# Altium schematic sheet Sensors_Sch.SchDoc(Sensors_Sch)
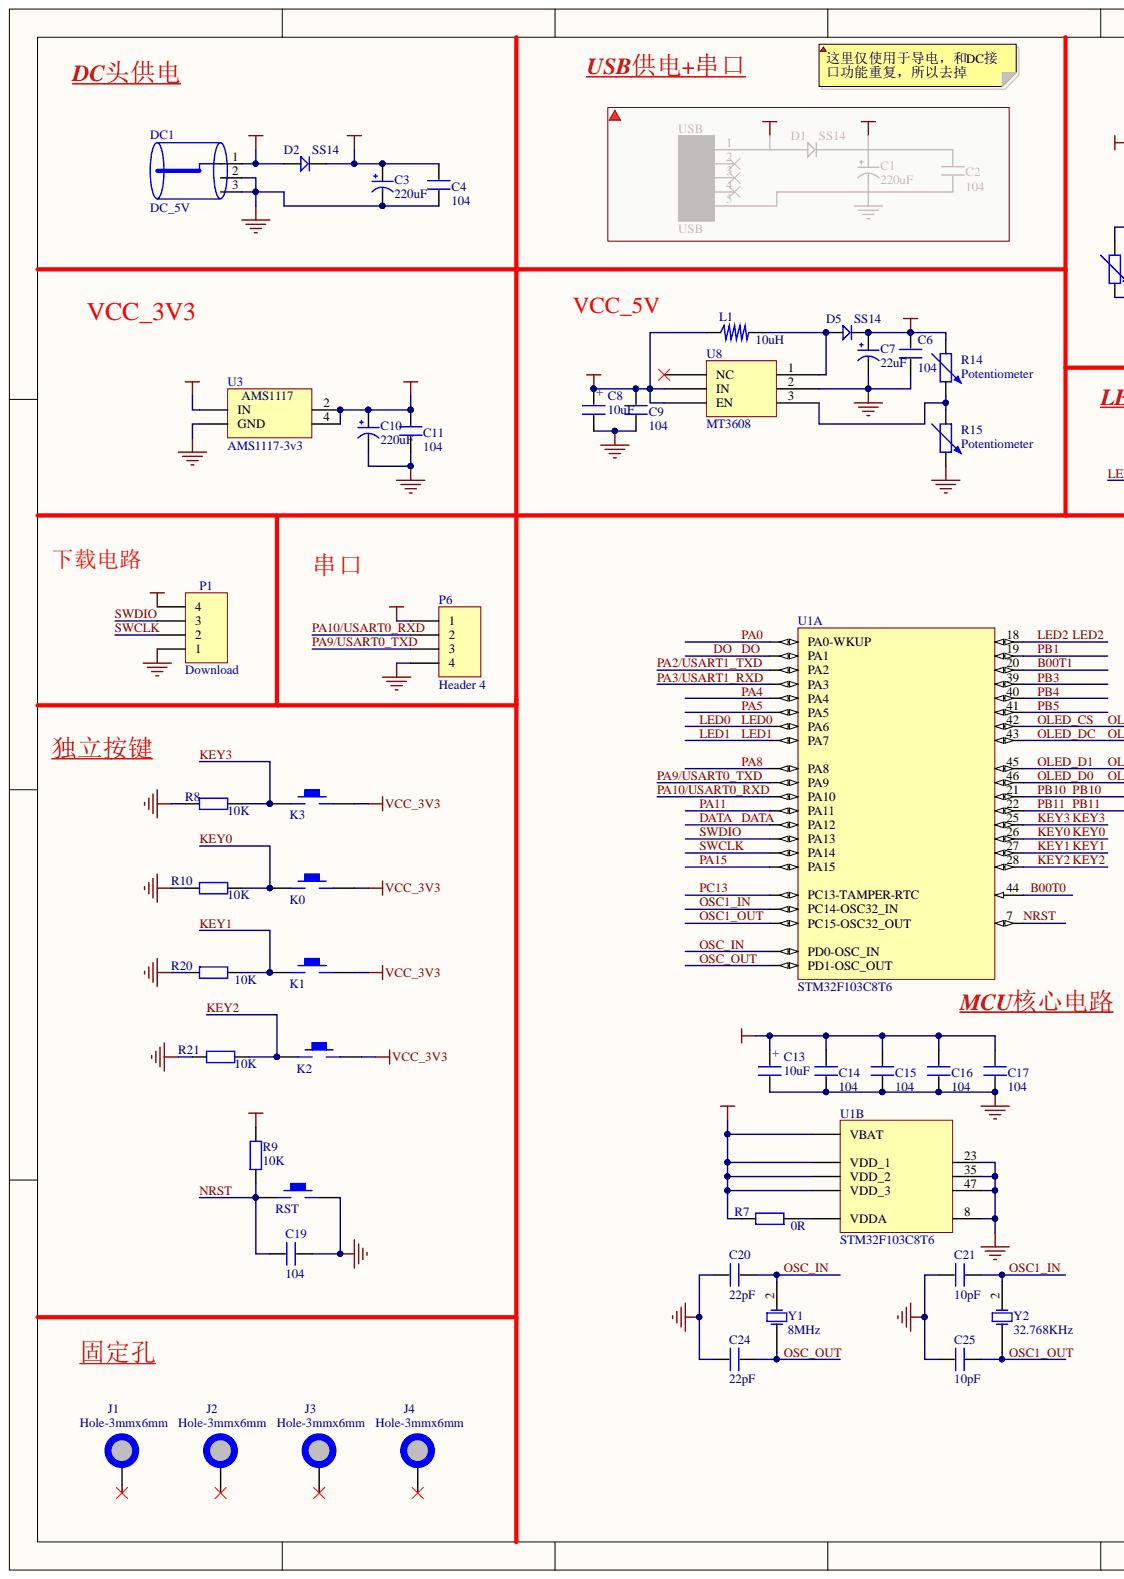
[diagram p0: Sensors_Sch.SchDoc, left]
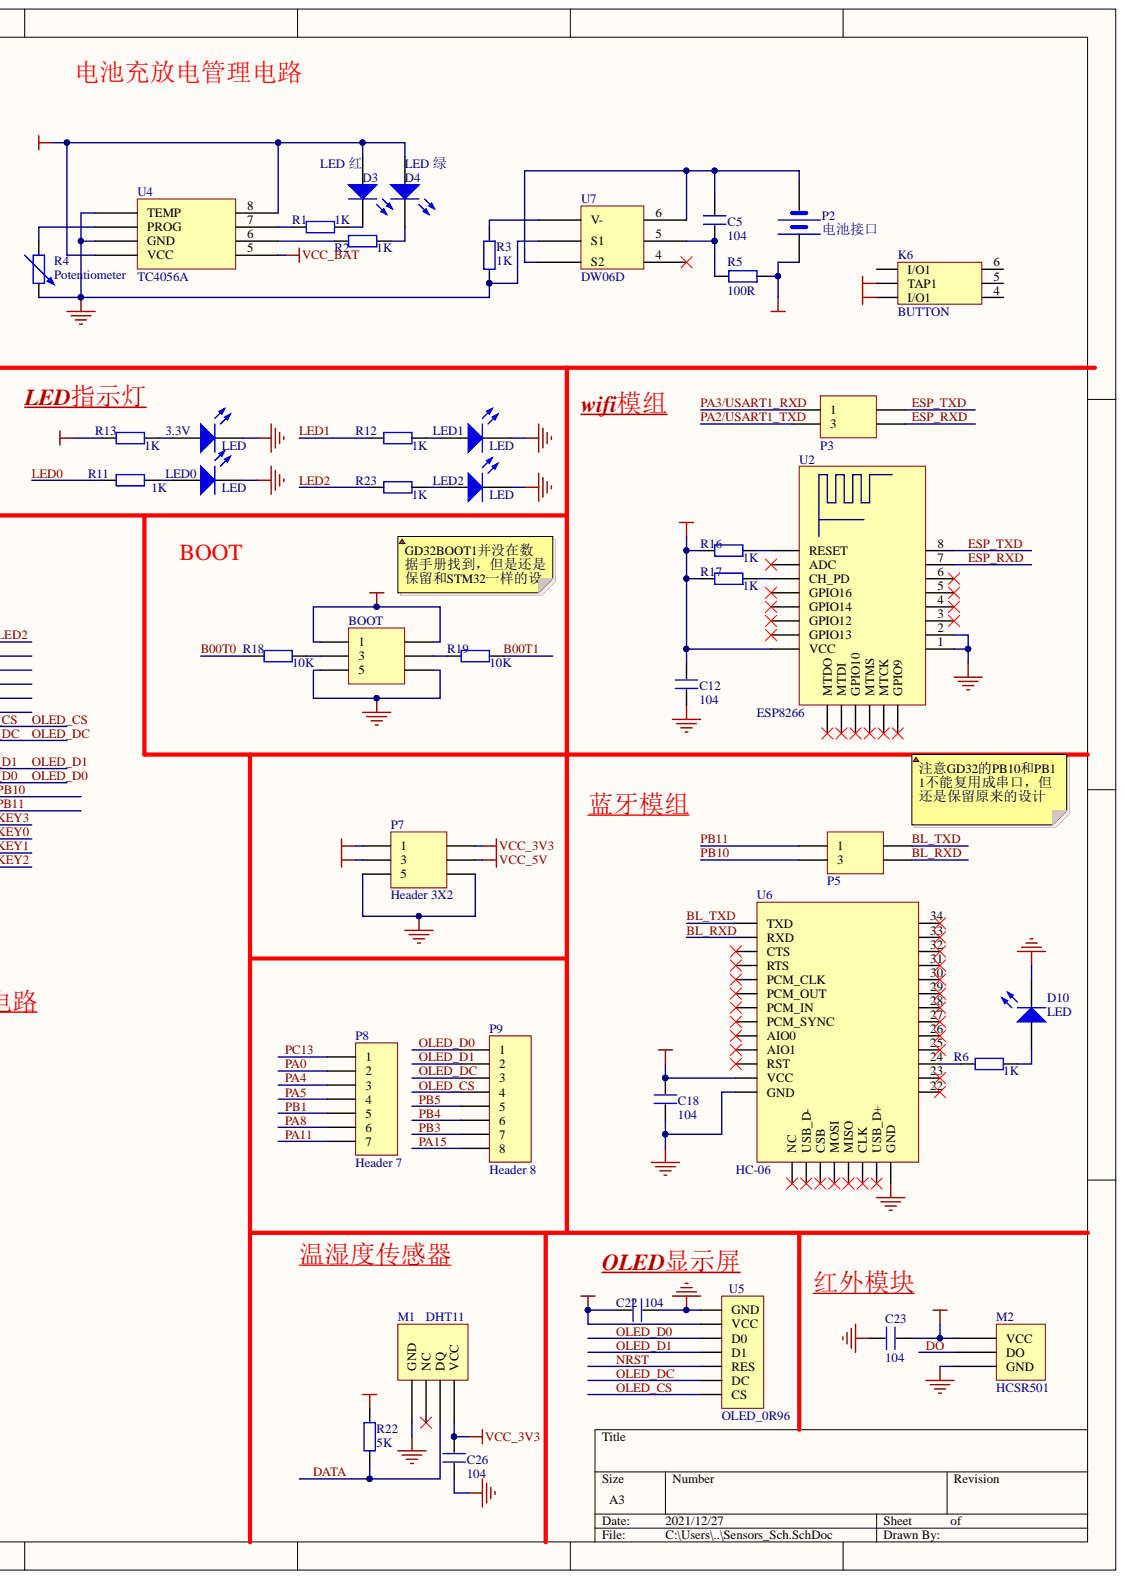
[diagram p1: Sensors_Sch.SchDoc, right]

## Parts
3.3V  LED  [LED_0805]
BOOT  Header 3X2  [HDR2X3]
C3  220uF  [CAP_220uF]
C4  104  [0805_C]
C5  104  [0805_C]
C6  104  [0805_C]
C7  22uF  [CAP_22uF]
C8  10uF  [c_Tantalum]
C9  104  [0805_C]
C10  220uF  [CAP_220uF]
C11  104  [0805_C]
C12  104  [0805_C]
C13  10uF  [c_Tantalum]
C14  104  [C0603]
C15  104  [C0603]
C16  104  [C0603]
C17  104  [C0603]
C18  104  [0805_C]
C19  104  [0805_C]
C20  22pF  [C0603]
C21  10pF  [C0603]
C22  104  [0805_C]
C23  104  [0805_C]
C24  22pF  [C0603]
C25  10pF  [C0603]
C26  104  [0805_C]
D2  SS14  [DIODE]
D3  LED 红  [LED_0805]
D4  LED 绿  [LED_0805]
D5  SS14  [DIODE]
D10  LED  [LED_0805]
DC1  DC_5V  [DC_POWER]
J1  Hole-3mmx6mm  [M3x7+6_L]
J2  Hole-3mmx6mm  [M3x7+6_L]
J3  Hole-3mmx6mm  [M3x7+6_L]
J4  Hole-3mmx6mm  [M3x7+6_L]
K0  KEY_M  [KEY]  (value not drawn)
K1  KEY_M  [KEY]  (value not drawn)
K2  KEY_M  [KEY]  (value not drawn)
K3  KEY_M  [KEY]  (value not drawn)
K6  BUTTON  [BTN8.5*8.5]
L1  10uH  [L]
LED0  LED  [LED_0805]
LED1  LED  [LED_0805]
LED2  LED  [LED_0805]
M1  DHT11  [DHT11]
M2  HCSR501  [HC-SR501]
P1  Download  [HDR1X4]
P2  电池接口  [电池接口]
P3  Header 2X2  [HDR2X2]  (value not drawn)
P5  Header 2X2  [HDR2X2]  (value not drawn)
P6  Header 4  [HDR1X4]
P7  Header 3X2  [HDR2X3]
P8  Header 7  [HDR1X7]
P9  Header 8  [HDR1X8]
R1  1K  [0805_R]
R2  1K  [0805_R]
R3  1K  [0805_R]
R4  Potentiometer  [Potentiometer]
R5  100R  [0805_R]
R6  1K  [0805_R]
R7  0R  [0805_R]
R8  10K  [0805_R]
R9  10K  [0805_R]
R10  10K  [0805_R]
R11  1K  [0805_R]
R12  1K  [0805_R]
R13  1K  [0805_R]
R14  Potentiometer  [Potentiometer]
R15  Potentiometer  [Potentiometer]
R16  1K  [0805_R]
R17  1K  [0805_R]
R18  10K  [0805_R]
R19  10K  [0805_R]
R20  10K  [0805_R]
R21  10K  [0805_R]
R22  5K  [0805_R]
R23  1K  [0805_R]
RST  KEY_M  [KEY]  (value not drawn)
U1  STM32F103C8T6  [LQFP48 7X7_N]  (parts A,B)
U2  ESP8266  [ESP8266]
U3  AMS1117-3v3  [SOT223_L]
U4  TC4056A  [TC4056A]
U5  OLED_0R96  [OLED_0.96_SPI]
U6  HC-06  [HC-06]
U7  DW06D  [SOT-23-6]
U8  MT3608  [SOT-23-6]
Y1  8MHz  [XTAL_US]
Y2  32.768KHz  [Crystal_2x6_DIP]

## Nets
5V: C3.1 C4.2 D2.2 D3.1 D4.1 U4.4(VCC) U4.8
BAT: C8.2 C9.2 K6.2(TAP1) L1.1 U3.3(IN) U8.4(EN) U8.5(IN)
GND: 3.3V.2 BOOT.5 BOOT.6 C3.2 C4.1 C6.1 C7.2 C8.1 C9.1 C10.2 C11.1 C12.1 C13.1 C14.1 C15.1 C16.1 C17.1 C18.2 C19.1 C20.1 C21.1 C22.1 C23.2 C24.1 C25.1 C26.2 D10.2 DC1.2 DC1.3 LED0.2 LED1.2 LED2.2 M1.4(GND) M2.1 P1.1 P6.4 P7.5 P7.6 R3.1 R4.1 R8.2 R10.2 R15.1 R20.2 R21.2 RST.2 U1.8 U1.23 U1.35 U1.47 U2.1 U2.2 U3.1(GND) U4.1(TEMP) U4.3(GND) U5.1(GND) U6.13(GND) U6.21(GND) U7.2(S1) U8.2
VCC_3V3: BOOT.1 BOOT.2 C10.1 C11.2 C12.2 C13.2 C14.2 C15.2 C16.2 C17.2 C18.1 C22.2 C26.1 K0.2 K1.2 K2.2 K3.2 M1.1(VCC) P1.4 P6.1 P7.1 P7.2 R7.1 R9.1 R13.2 R16.1 R17.1 R22.2 U1.1(VBAT) U1.24(VDD_1) U1.36(VDD_2) U1.48(VDD_3) U2.16(VCC) U3.2 U3.4 U5.2(VCC) U6.12(VCC)
VCC_5V: C6.2 C7.1 C23.1 D5.2 M2.3 P7.3 P7.4 R14.2
VCC_BAT: K6.3(I/O1) P2.1 R5.2 U4.5
VCC_DC: D2.1 DC1.1
B00T0: R18.1 U1.44
B00T1: R19.2 U1.20
BL_RXD: P5.4 U6.2(RXD)
BL_TXD: P5.2 U6.1(TXD)
DATA: M1.2(DQ) R22.1 U1.33(PA12)
DO: M2.2 U1.11(PA1)
ESP_RXD: P3.4 U2.7
ESP_TXD: P3.2 U2.8
KEY0: K0.1 R10.1 U1.26
KEY1: K1.1 R20.1 U1.27
KEY2: K2.1 R21.1 U1.28
KEY3: K3.1 R8.1 U1.25
LED0: R11.2 U1.16(PA6)
LED1: R12.2 U1.17(PA7)
LED2: R23.2 U1.18
NRST: C19.2 R9.2 RST.1 U1.7 U5.5(RES)
OLED_CS: P9.4 U1.42 U5.7(CS)
OLED_D0: P9.1 U1.46 U5.3(D0)
OLED_D1: P9.2 U1.45 U5.4(D1)
OLED_DC: P9.3 U1.43 U5.6(DC)
OSC1_IN: C21.2 U1.3(PC14-OSC32_IN) Y2.2
OSC1_OUT: C25.2 U1.4(PC15-OSC32_OUT) Y2.1
OSC_IN: C20.2 U1.5(PD0-OSC_IN) Y1.2
OSC_OUT: C24.2 U1.6(PD1-OSC_OUT) Y1.1
PA0: P8.2 U1.10(PA0-WKUP)
PA2/USART1_TXD: P3.3 U1.12(PA2)
PA3/USART1_RXD: P3.1 U1.13(PA3)
PA4: P8.3 U1.14(PA4)
PA5: P8.4 U1.15(PA5)
PA8: P8.6 U1.29(PA8)
PA9/USART0_TXD: P6.3 U1.30(PA9)
PA10/USART0_RXD: P6.2 U1.31(PA10)
PA11: P8.7 U1.32(PA11)
PA15: P9.8 U1.38(PA15)
PB1: P8.5 U1.19
PB3: P9.7 U1.39
PB4: P9.6 U1.40
PB5: P9.5 U1.41
PB10: P5.3 U1.21
PB11: P5.1 U1.22
PC13: P8.1 U1.2(PC13-TAMPER-RTC)
SWCLK: P1.2 U1.37(PA14)
SWDIO: P1.3 U1.34(PA13)
Net3.3V_1: 3.3V.1 R13.1
NetBOOT_3: BOOT.3 R18.2
NetBOOT_4: BOOT.4 R19.1
NetC5_1: C5.1 R5.1 U7.5
NetC5_2: C5.2 P2.2 U7.3(S2) U7.6
NetD3_2: D3.2 R1.2
NetD4_2: D4.2 R2.2
NetD5_1: D5.1 L1.2 U8.1
NetD10_1: D10.1 R6.2
NetLED0_1: LED0.1 R11.1
NetLED1_1: LED1.1 R12.1
NetLED2_1: LED2.1 R23.1
NetR1_1: R1.1 U4.7
NetR2_1: R2.1 U4.6
NetR3_2: R3.2 U7.1(V-)
NetR4_2: R4.2 U4.2(PROG)
NetR6_1: R6.1 U6.24
NetR7_2: R7.2 U1.9(VDDA)
NetR14_1: R14.1 R15.2 U8.3
NetR16_2: R16.2 U2.9(RESET)
NetR17_2: R17.2 U2.11(CH_PD)
VCC_USB:

Not connected: J1 0; J2 0; J3 0; J4 0; K6 1(I/O1) 4 5 6; M1 3(NC); U2 3 4 5 6 10(ADC) 12(GPIO16) 13(GPIO14) 14(GPIO12) 15(GPIO13) 17(MTDO) 18(MTDI) 19(GPIO10) 20(MTMS) 21(MTCK) 22(GPIO9); U6 3(CTS) 4(RTS) 5(PCM_CLK) 6(PCM_OUT) 7(PCM_IN) 8(PCM_SYNC) 9(AIO0) 10(AIO1) 11(RST) 14(NC) 15(USB_D-) 16(CSB) 17(MOSI) 18(MISO) 19(CLK) 20(USB_D+) 22 23 25 26 27 28 29 30 31 32 33 34; U7 4; U8 6(NC)

## Library data of the parts
3.3V D3 D4 D10 LED0-LED2 = LED: 发光二极管; SuppliersPartNumber: C2293; Supplier: LC; Package: 0805; Library Name: Sensors_Board.SchLib; ComponentLink1URL: http://www.szlcsc.com/product/details_2666.html; ComponentLink1Description: 供应商链接; Component Kind: Standard
BOOT P7 = Header 3X2: Header, 3-Pin, Dual row; Library Name: Sensors_Board.SchLib; LatestRevisionNote: Re-released for DXP Platform.; LatestRevisionDate: 17-Jul-2002; Component Kind: Standard; Publisher: Altium Limited
C3 C7 C10 = CAP: 电解电容; Library Name: Sensors_Board.SchLib; Component Kind: Standard
C4-C6 C9 C11 C12 C14-C26 = C: Library Name: Sensors_Board.SchLib; Component Kind: Standard
C8 C13 = C_Tantalum: 钽电容; Library Name: Sensors_Board.SchLib; Component Kind: Standard
D2 D5 = D: Library Name: Sensors_Board.SchLib; Component Kind: Standard
DC1 = DC_5V: DC005; Manufacturer Part Number: K-DC-0052.5; Manufacturer: TruConnect; Mount: Socket; Component Kind: Standard; Library Name: Desiger_Schlib.SchLib
J1-J4 = HOLED: Component Kind: Standard; Library Name: Desiger_Schlib.SchLib
L1 = L: 电感; Library Name: Sensors_Board.SchLib; Component Kind: Standard
M1 = DHT11: 温湿度传感器; Library Name: Sensors_Board.SchLib; Component Kind: Standard
M2 = HCSR501: 人体红外感应模块; Library Name: Sensors_Board.SchLib; Component Kind: Standard
P1 P6 = Header 4: Header, 4-Pin; Signal Integrity: Connector; LatestRevisionNote: Re-released for DXP Platform.; LatestRevisionDate: 17-Jul-2002; Component Kind: Standard; Publisher: Altium Limited
  P1: Library Name: Miscellaneous Connectors.IntLib
  P6: Library Name: Sensors_Board.SchLib
P2 = 电池接口: 两个焊盘; Library Name: Sensors_Board.SchLib; LatestRevisionNote: Re-released for DXP Platform.; LatestRevisionDate: 17-Jul-2002; Component Kind: Standard; Publisher: Altium Limited
P3 P5 = Header 2X2: Header, 2-Pin, Dual row; Library Name: Sensors_Board.SchLib; LatestRevisionNote: Re-released for DXP Platform.; LatestRevisionDate: 17-Jul-2002; Component Kind: Standard; Publisher: Altium Limited
P8 = Header 7: Header, 7-Pin; Signal Integrity: Connector; Library Name: Miscellaneous Connectors.IntLib; LatestRevisionNote: Re-released for DXP Platform.; LatestRevisionDate: 17-Jul-2002; Component Kind: Standard; Publisher: Altium Limited
P9 = Header 8: Header, 8-Pin; Signal Integrity: Connector; Library Name: Miscellaneous Connectors.IntLib; LatestRevisionNote: Re-released for DXP Platform.; LatestRevisionDate: 17-Jul-2002; Component Kind: Standard; Publisher: Altium Limited
R1-R3 R5-R13 R16-R23 = R: 贴片电阻; 版权归属: 源创客; 学习资源: www.ooocke.com; Library Name: Sensors_Board.SchLib; Component Kind: Standard; BOM名称: 贴片电阻
R4 R14 R15 = Potentiometer: 滑动变阻器; 版权归属: 源创客; BOM名称: 贴片电阻; 学习资源: www.ooocke.com; Library Name: Sensors_Board.SchLib; Component Kind: Standard
U1 = STM32F103C8T6: ARM Cortex-M3 32-bit MCU, 64 KB Flash, 20 KB Internal RAM, 37 I/Os, 48-pin LQFP, -40 to 85 degC, Tray; Supply Voltage(Vcc) min (V): 2; Operating Frequency(F) (Processor speed) (MHz): 72; Internal RAM Size (kB): 20; I/Os (High Current): 37; FLASH Size (Prog) (kB): 64; A/D Converter: 2x12-bit (10 Channel); Supplier Part Number 5: 511-STM32F103C8T6; Supplier Part Number 4: 57P1222; Supplier Part Number 3: 59M2182; Supplier Part Number 2: 1447637; Supplier Part Number 1: 497-6063-ND; Supplier 5: Mouser; Supplier 4: Newark; Supplier 3: Newark; Supplier 2: Farnell; Supplier 1: Digi-Key; PartNumber: STM32F103C8T6; Packing: Tray; PackageVersion: Rev. 6, 06/2013; PackageReference: LQFP48; PackageDescription: 48-Pin Low Profile Quad Flat Package, 7 x 7 mm Body, 0.5 mm Pitch; Mounting Technology: Surface Mount; Library Name: STMicroelectronics STM32 F1.IntLib; DatasheetVersion: Rev. 16; Core: ARM Cortex-M3; ComponentLink3URL: http://www.st.com/st-web-ui/static/active/en/resource/technical/document/datasheet/DM00058181.pdf; ComponentLink3Description: Package Specification; ComponentLink2URL: http://www.st.com/st-web-ui/static/active/en/resource/technical/document/datasheet/CD00161566.pdf; ComponentLink2Description: Datasheet; ComponentLink1URL: http://www.st.com/web/en/home.html; ComponentLink1Description: Manufacturer URL; Component Kind: Standard
U2 = ESP8266 Module: Component Kind: Standard; Library Name: Desiger_Schlib.SchLib
U4 = TC4056A: 锂电池充电IC; Library Name: Sensors_Board.SchLib; Component Kind: Standard
U5 = OLED_7P_0R96: Component Kind: Standard; Library Name: Desiger_Schlib.SchLib
U6 = HC-06 Module: Component Kind: Standard; Library Name: Desiger_Schlib.SchLib
U7 = DW06D: 锂电池保护IC; Library Name: Sensors_Board.SchLib; Component Kind: Standard
U8 = MT3608: DC-DC芯片; Library Name: Sensors_Board.SchLib; Component Kind: Standard
Y1 Y2 = XTAL: Crystal Oscillator; Published: 8-Jun-2000; Library Name: Sensors_Board.SchLib; LatestRevisionNote: Re-released for DXP Platform.; LatestRevisionDate: 17-Jul-2002; Component Kind: Standard; Publisher: Altium Limited
K0-K3 RST = KEY_M: Library Name: Sensors_Board.SchLib; Component Kind: Standard
K6 = BUTTON: Library Name: Sensors_Board.SchLib; Component Kind: Standard
U3 = AMS1117-3v3: Component Kind: Standard; Library Name: Desiger_Schlib.SchLib
